# Altium schematic sheet WPT Round 2.1 RX Schematics.SchDoc
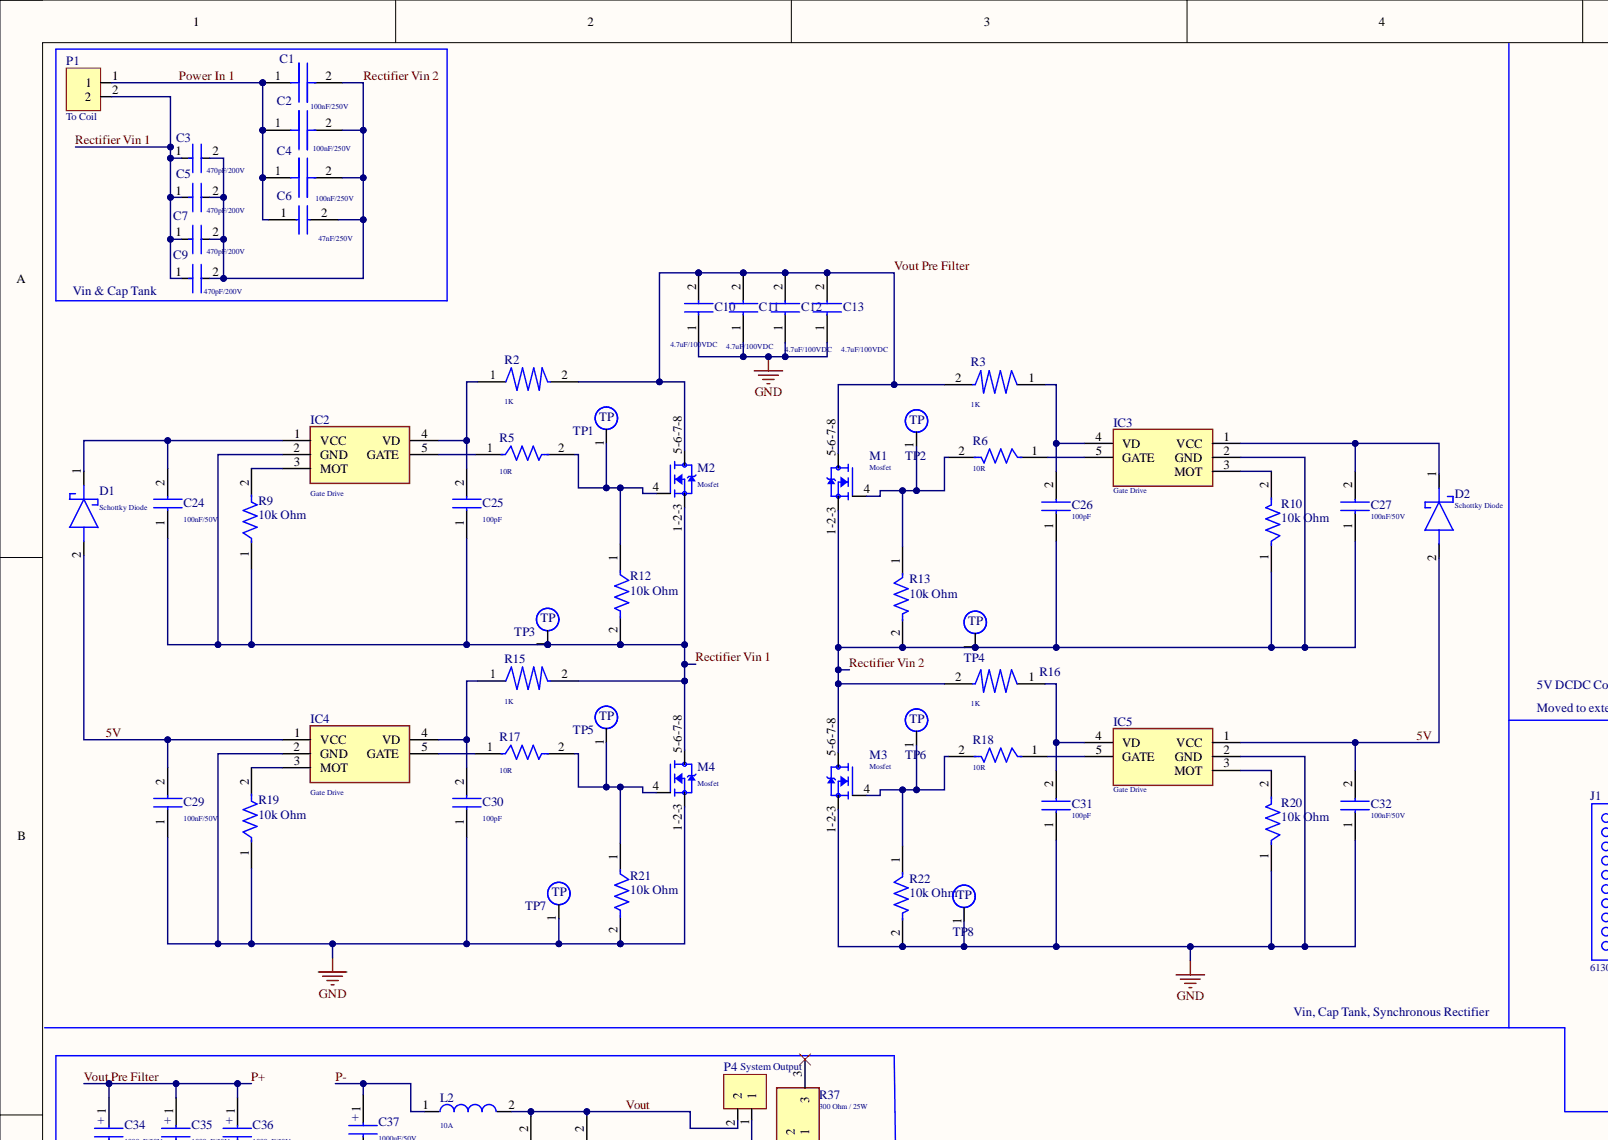
[diagram p0: WPT Round 2.1 RX Schematics.SchDoc, top left]
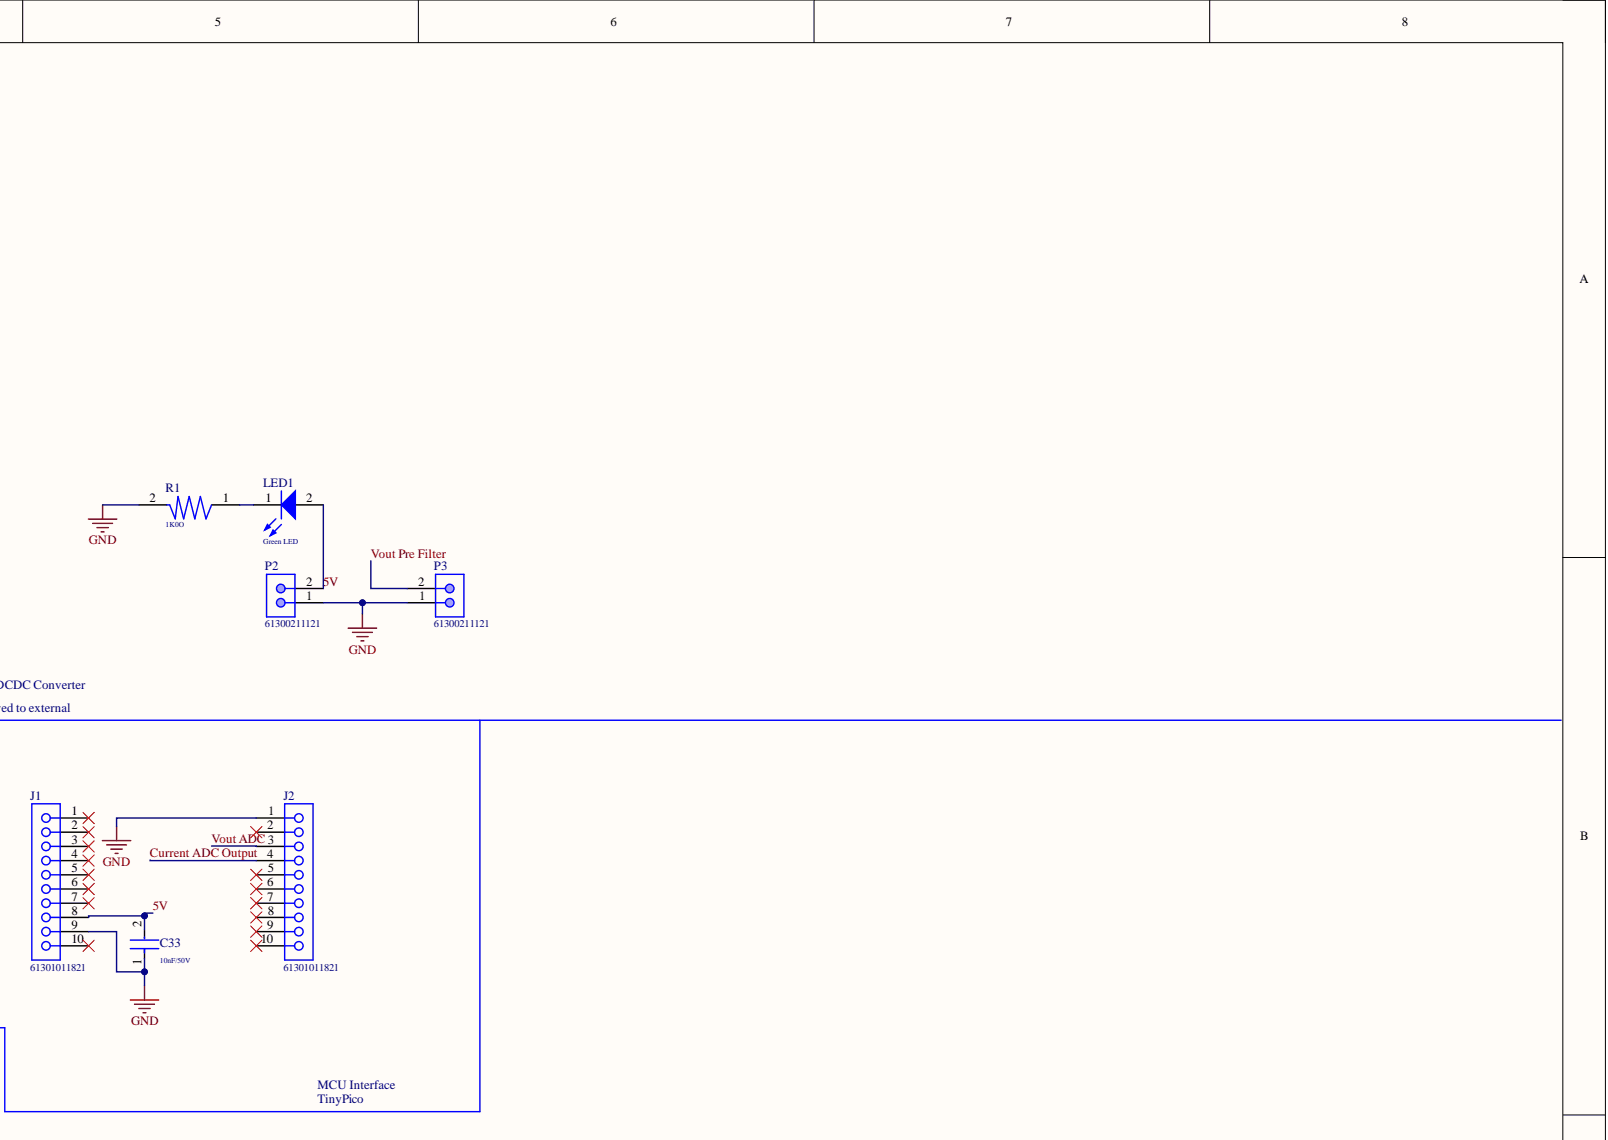
[diagram p1: WPT Round 2.1 RX Schematics.SchDoc, top right]
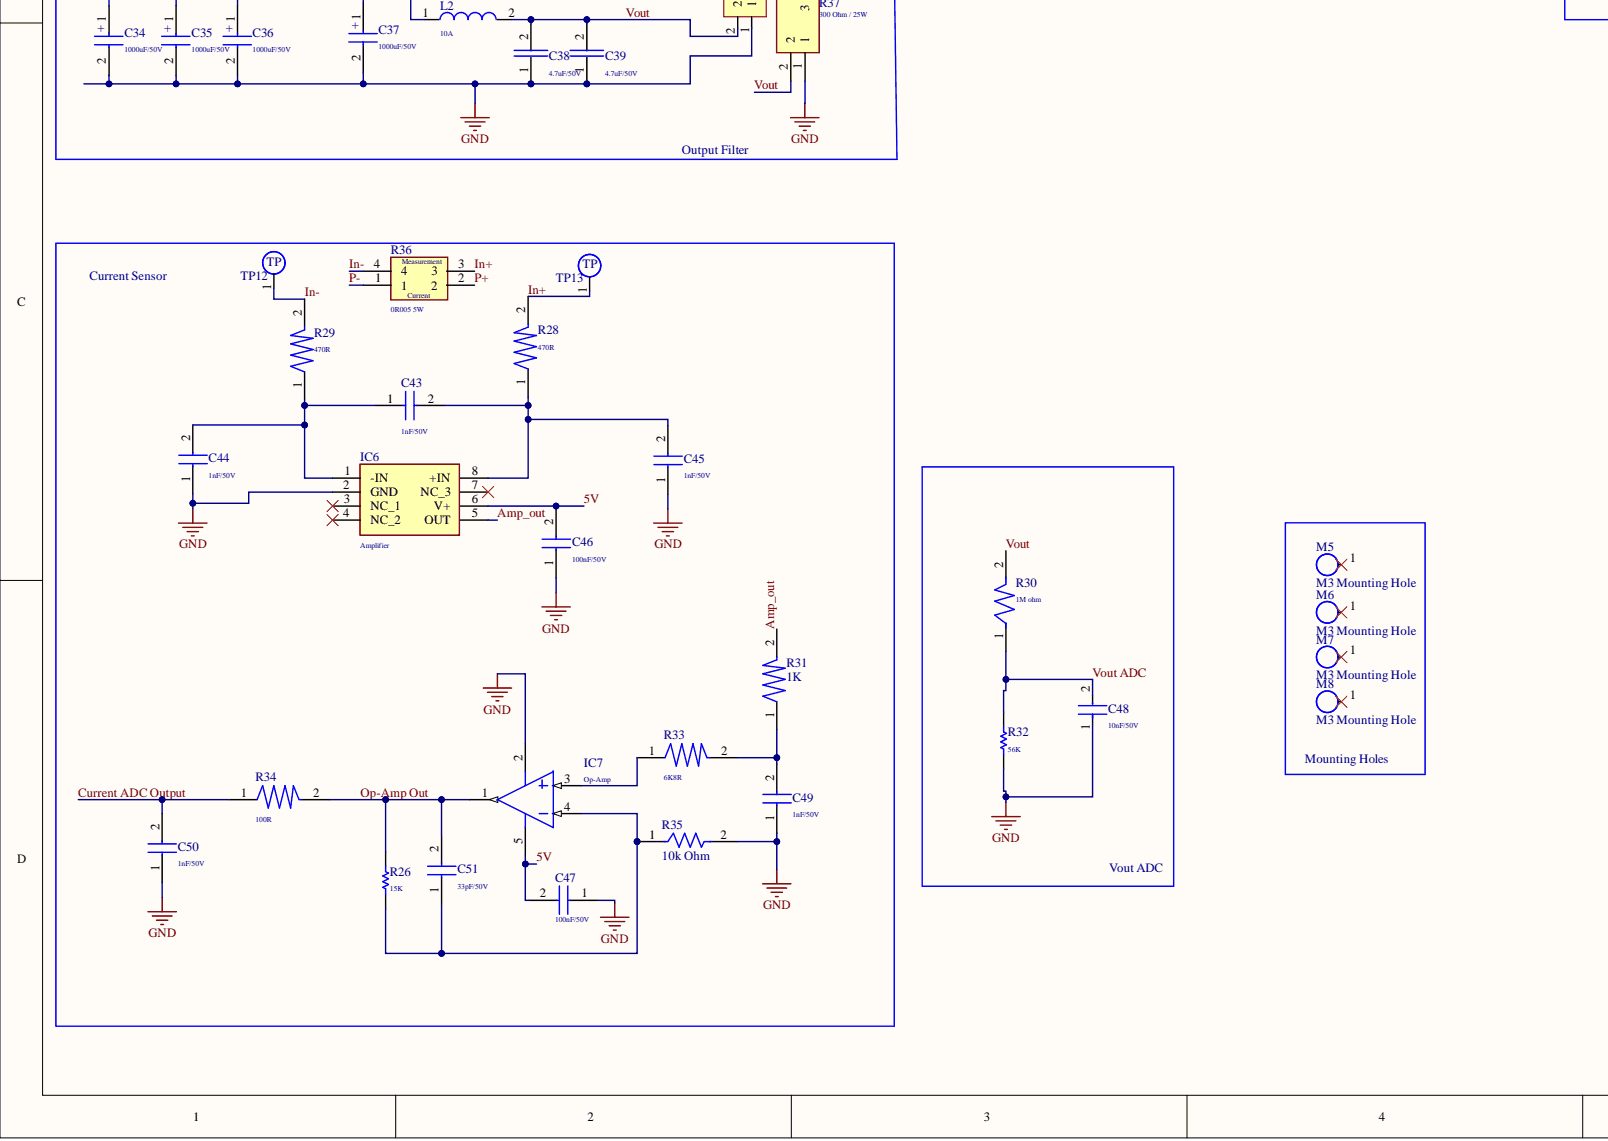
[diagram p2: WPT Round 2.1 RX Schematics.SchDoc, bottom left]
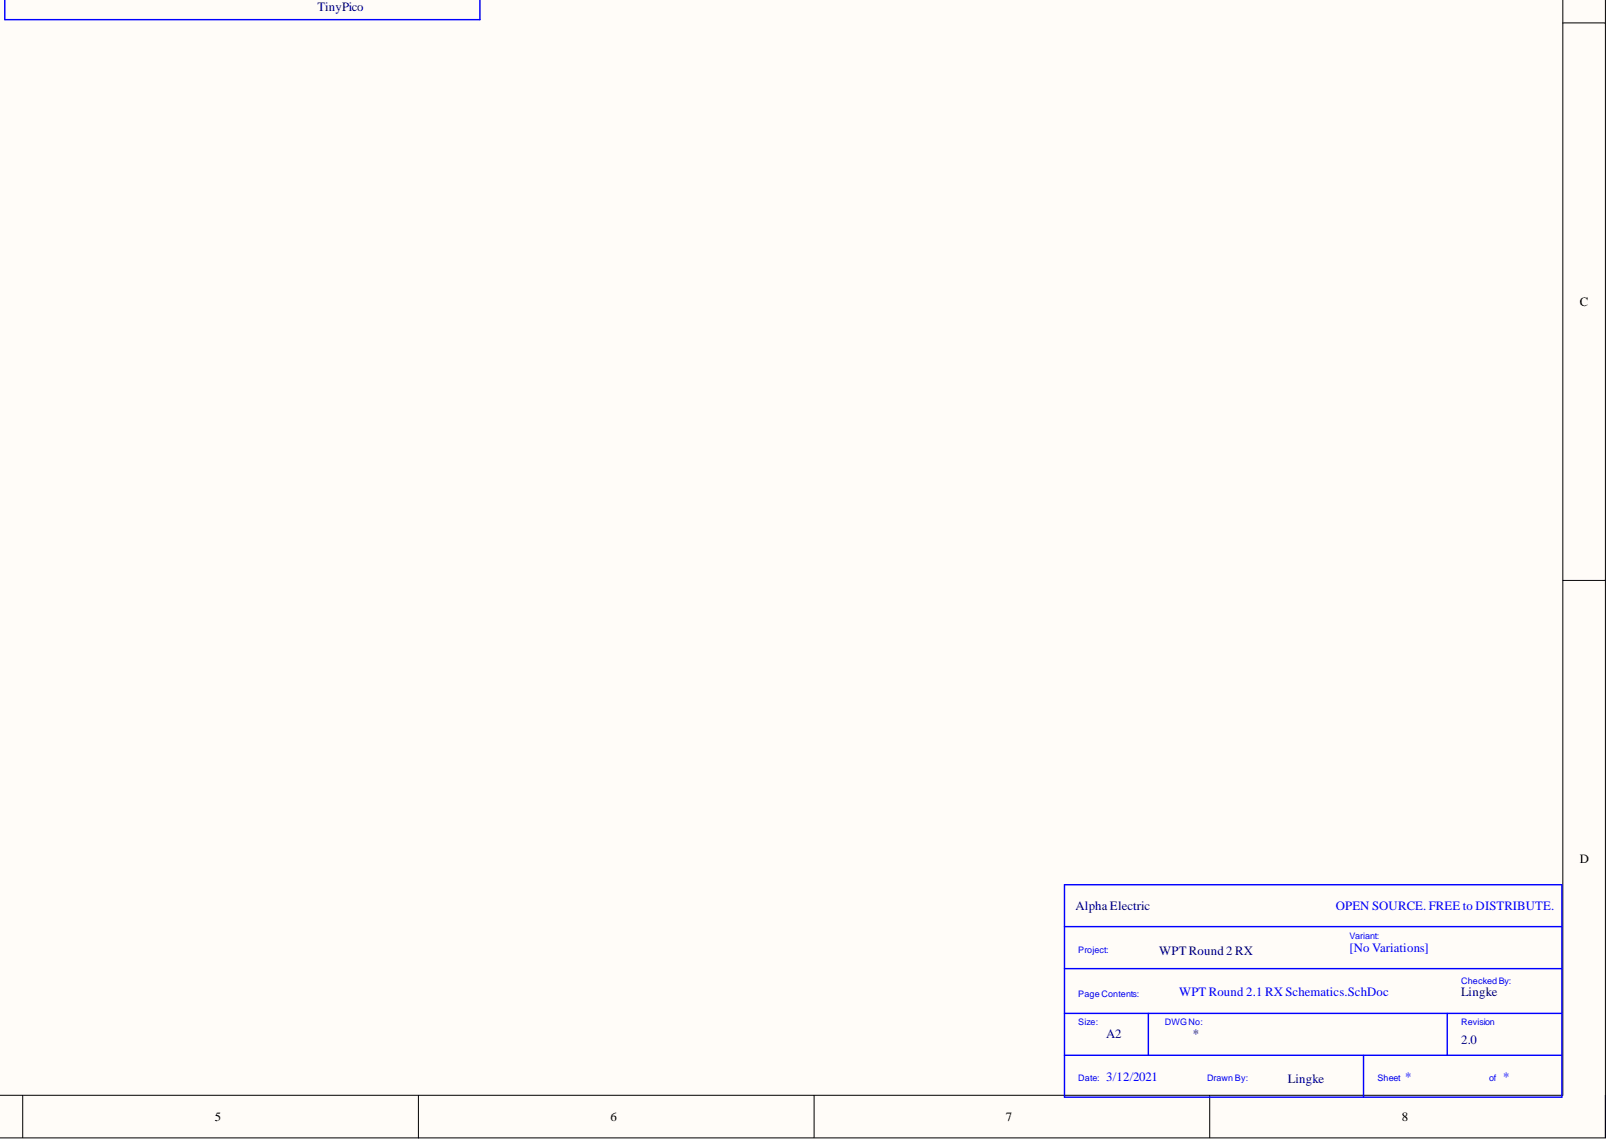
[diagram p3: WPT Round 2.1 RX Schematics.SchDoc, bottom right]

## Parts
*  Checked By: Lingke  Company: Alpha Electric  Project Name: WPT Round 2 RX  Revision: 2.0  Size: A2  (hidden comment: Title_Block)  (no BOM)
C1  100nF/250V  [CAPC4532X220N]
C2  100nF/250V  [CAPC4532X220N]
C3  470pF/200V  [FP-C1206C-EB-MFG]
C4  100nF/250V  [CAPC4532X220N]
C5  470pF/200V  [FP-C1206C-EB-MFG]
C6  47nF/250V  [CAPC4532X350N]
C7  470pF/200V  [FP-C1206C-EB-MFG]
C9  470pF/200V  [FP-C1206C-EB-MFG]
C10  4.7uF/100VDC  [CAPC3225X280N]
C11  4.7uF/100VDC  [CAPC3225X280N]
C12  4.7uF/100VDC  [CAPC3225X280N]
C13  4.7uF/100VDC  [CAPC3225X280N]
C24  100nF/50V  [CAPC2012X90N]
C25  100pF  [CAPC2012X70N]
C26  100pF  [CAPC2012X70N]
C27  100nF/50V  [CAPC2012X90N]
C29  100nF/50V  [CAPC2012X90N]
C30  100pF  [CAPC2012X70N]
C31  100pF  [CAPC2012X70N]
C32  100nF/50V  [CAPC2012X90N]
C33  10nF/50V  [0805 Capacitor]
C34  1000uF/50V  [CAPPRD500W62D1300H2650]
C35  1000uF/50V  [CAPPRD500W62D1300H2650]
C36  1000uF/50V  [CAPPRD500W62D1300H2650]
C37  1000uF/50V  [CAPPRD500W62D1300H2650]
C38  4.7uF/50V  [CAPC3225X280N]
C39  4.7uF/50V  [CAPC3225X280N]
C43  1nF/50V  [CAPC2012X90N]
C44  1nF/50V  [CAPC2012X90N]
C45  1nF/50V  [CAPC2012X90N]
C46  100nF/50V  [CAPC2012X90N]
C47  100nF/50V  [CAPC2012X90N]
C48  10nF/50V  [0805 Capacitor]
C49  1nF/50V  [CAPC2012X90N]
C50  1nF/50V  [CAPC2012X90N]
C51  33pF/50V  [CAPC2012X90N]
D1  Schottky Diode  [RB400VAM50TR]
D2  Schottky Diode  [RB400VAM50TR]
IC2  Gate Drive  [SOT95P280X145-5N]
IC3  Gate Drive  [SOT95P280X145-5N]
IC4  Gate Drive  [SOT95P280X145-5N]
IC5  Gate Drive  [SOT95P280X145-5N]
IC6  Amplifier  [SOIC127P600X175-8N]
IC7  Op-Amp  [ADI-RJ-5_N]
J1  61301011821  [61301011821]
J2  61301011821  [61301011821]
L2  10A  [WE-PF_1280]
LED1  Green LED  Color: Green  [0805_A]
M1  Mosfet  [TRANS_BSZ037N06LS5ATMA1]
M2  Mosfet  [TRANS_BSZ037N06LS5ATMA1]
M3  Mosfet  [TRANS_BSZ037N06LS5ATMA1]
M4  Mosfet  [TRANS_BSZ037N06LS5ATMA1]
M5  M3 Mounting Hole  [M3 Hole]
M6  M3 Mounting Hole  [M3 Hole]
M7  M3 Mounting Hole  [M3 Hole]
M8  M3 Mounting Hole  [M3 Hole]
P1  To Coil  [691404910002B]
P2  61300211121  [61300211121]
P3  61300211121  [61300211121]
P4  System Output  [691404910002B]
R1  1K0O  [FP-CRCW0805-e3-MFG]
R2  1K  [FP-CRCW0805-e3-MFG]
R3  1K  [FP-CRCW0805-e3-MFG]
R5  10R  [FP-CRCW1206-e3-IPC_A]
R6  10R  [FP-CRCW1206-e3-IPC_A]
R9  10k Ohm  [0805 Resistor]
R10  10k Ohm  [0805 Resistor]
R12  10k Ohm  [0805 Resistor]
R13  10k Ohm  [0805 Resistor]
R15  1K  [FP-CRCW0805-e3-MFG]
R16  1K  [FP-CRCW0805-e3-MFG]
R17  10R  [FP-CRCW1206-e3-IPC_A]
R18  10R  [FP-CRCW1206-e3-IPC_A]
R19  10k Ohm  [0805 Resistor]
R20  10k Ohm  [0805 Resistor]
R21  10k Ohm  [0805 Resistor]
R22  10k Ohm  [0805 Resistor]
R26  15K  [0805 Resistor]
R28  470R  [FP-CRCW0805-e3-MFG]
R29  470R  [FP-CRCW0805-e3-MFG]
R30  1M ohm  [FP-CRCW0805-e3-MFG]
R31  1K  [FP-CRCW0805-e3-MFG]
R32  56K  [0805 Resistor]
R33  6K8R  [FP-CRCW0805-e3-MFG]
R34  100R  [FP-CRCW0805-e3-MFG]
R35  10k Ohm  [0805 Resistor]
R36  0R005 5W  [FC4L110R005FER]
R37  300 Ohm / 25W  [PWR163S253000FE]
TP1  TP  [Test Point]  (no BOM)
TP2  TP  [Test Point]  (no BOM)
TP3  TP  [Test Point]  (no BOM)
TP4  TP  [Test Point]  (no BOM)
TP5  TP  [Test Point]  (no BOM)
TP6  TP  [Test Point]  (no BOM)
TP7  TP  [Test Point]  (no BOM)
TP8  TP  [Test Point]  (no BOM)
TP12  TP  [Test Point]  (no BOM)
TP13  TP  [Test Point]  (no BOM)

## Nets
GND: C10.1 C11.1 C12.1 C13.1 C29.1 C30.1 C31.1 C32.1 C33.1 C34.2 C35.2 C36.2 C37.2 C38.1 C39.1 C44.1 C45.1 C46.1 C47.1 C48.1 C49.1 C50.1 IC4.2(GND) IC5.2(GND) IC6.2(GND) IC7.2 J1.9 J2.1 M3.1-2-3 M4.1-2-3 P2.1 P3.1 P4.1 R1.2 R19.1 R20.1 R21.2 R22.2 R32.1 R35.2 R37.1 TP7.1 TP8.1
5V: C29.2 C32.2 C33.2 C46.2 C47.2 D1.2 D2.2 IC4.1(VCC) IC5.1(VCC) IC6.6(V+) IC7.5 J1.8 LED1.2 P2.2
Amp_out: IC6.5(OUT) R31.2
Current ADC Output: C50.2 J2.4 R34.1
In+: R28.2 R36.3 TP13.1
In-: R29.2 R36.4 TP12.1
Op-Amp Out: C51.2 IC7.1 R26.2 R34.2
P+: C10.2 C11.2 C12.2 C13.2 C34.1 C35.1 C36.1 M1.5-6-7-8 M2.5-6-7-8 P3.2 R2.2 R3.2 R36.2
P-: C37.1 L2.1 R36.1
Power In 1: C1.1 C2.1 C4.1 C6.1 P1.1
Rectifier Vin 1: C3.1 C5.1 C7.1 C9.1 C24.1 C25.1 IC2.2(GND) M2.1-2-3 M4.5-6-7-8 P1.2 R9.1 R12.2 R15.2 TP3.1
Rectifier Vin 2: C1.2 C2.2 C3.2 C4.2 C5.2 C6.2 C7.2 C9.2 C26.1 C27.1 IC3.2(GND) M1.1-2-3 M3.5-6-7-8 R10.1 R13.2 R16.2 TP4.1
Vout: C38.2 C39.2 L2.2 P4.2 R30.2 R37.2
Vout ADC: C48.2 J2.3 R30.1 R32.2
NetC24_2: C24.2 D1.1 IC2.1(VCC)
NetC25_2: C25.2 IC2.4(VD) R2.1
NetC26_2: C26.2 IC3.4(VD) R3.1
NetC27_2: C27.2 D2.1 IC3.1(VCC)
NetC30_2: C30.2 IC4.4(VD) R15.1
NetC31_2: C31.2 IC5.4(VD) R16.1
NetC43_1: C43.1 C44.2 IC6.1(-IN) R29.1
NetC43_2: C43.2 C45.2 IC6.8(+IN) R28.1
NetC49_2: C49.2 R31.1 R33.2
NetC51_1: C51.1 IC7.4 R26.1 R35.1
NetIC2_3: IC2.3(MOT) R9.2
NetIC2_5: IC2.5(GATE) R5.1
NetIC3_3: IC3.3(MOT) R10.2
NetIC3_5: IC3.5(GATE) R6.1
NetIC4_3: IC4.3(MOT) R19.2
NetIC4_5: IC4.5(GATE) R17.1
NetIC5_3: IC5.3(MOT) R20.2
NetIC5_5: IC5.5(GATE) R18.1
NetIC7_3: IC7.3 R33.1
NetLED1_1: LED1.1 R1.1
NetM1_4: M1.4 R6.2 R13.1 TP2.1
NetM2_4: M2.4 R5.2 R12.1 TP1.1
NetM3_4: M3.4 R18.2 R22.1 TP6.1
NetM4_4: M4.4 R17.2 R21.1 TP5.1

Not connected: IC6 3(NC_1) 4(NC_2) 7(NC_3); J1 1 2 3 4 5 6 7 10; J2 2 5 6 7 8 9 10; M5 1; M6 1; M7 1; M8 1; R37 3

## Library data of the parts
* = Title_Block: Standard Title Block.; Library Name: Symbol Library.SchLib; Drawn By: Lingke
C1 C2 C4 = C1812C104JAGACAUTO: Manufacturer_Name: Kemet; Component Kind: Standard; Datasheet Link: https://api.kemet.com/component-edge/download/datasheet/C1812C104JAGACAUTO.pdf; Description: 100nF/250V; Height: 2.2mm; Library Name: Symbol Library.SchLib; Manufacturer_Part_Number: C1812C104JAGACAUTO; Supplier 1: Mouser; Supplier Part Number 1: 80-C1812C104JAGAUTO
C3 C5 C7 C9 = C1206C471K2GACTU: CAP CER 470PF 200V 10% NP0 1206; Manufacturer: KEMET; Automotive Grade: Grade 0; Material: Ceramic; Temperature Characteristic: C0G; Temperature Coefficient: 15%; Tolerance: 0.1; Automotive: Yes; Capacitance: 470 pF; Category: Cap; Component Kind: Standard; ComponentLink2Description: Datasheet; ComponentLink2URL: https://content.kemet.com/datasheets/KEM_C1007_X8R_ULTRA_150C_SMD.pdf; Device Class L1: Passive Components; Device Class L2: Capacitors; Device Class L3: Ceramic Capacitors; Digikey Description: CAP CER 470PF 200V 10% NP0 1206; Height: 0.88mm; Ipc Land Pattern Name: CAPC320160X78; Lead Free: Yes; Library Name: Symbol Library.SchLib; Manufacturer Part Number: C1206C471K2GACTU; Mouser Description: Multilayer Ceramic Capacitors MLCC - SMD/SMT 200volts 470pF C0G 10%; Octopart: https://octopart.com/c1206c471k2gactu-kemet-3658280; Package: C1206C-EB; RoHS: TRUE; Temperature: -55°C to +150°C; Temperature Range High: +150°C; Temperature Range Low: -55°C; Value: 470 pF; Voltage Rating: 200 V
C6 = C4532C0G2E473J320KA: Capacitor  47nF/250V   C0G; Manufacturer_Name: TDK; Component Kind: Standard; Datasheet Link: https://product.tdk.com/info/en/catalog/datasheets/mlcc_commercial_midvoltage_en.pdf; Height: 3.5mm; Library Name: Symbol Library.SchLib; Manufacturer_Part_Number: C4532C0G2E473J320KA; Supplier 1: Mouser; Supplier Part Number 1: 810-C4532C0G2E473J
C10-C13 = CNC6P1X7R2A475K250AE: Manufacturer_Name: TDK; Component Kind: Standard; Datasheet Link: https://componentsearchengine.com//CNC6P1X7R2A475K250AE.pdf; Description: 4.7uF/100VDC; Height: 2.8mm; Library Name: Symbol Library.SchLib; Manufacturer_Part_Number: CNC6P1X7R2A475K250AE; Mouser2 Part Number: 810-CNC6P1X7R2A475K2; Mouser2 Price/Stock: https://www.mouser.com/Search/Refine.aspx?Keyword=810-CNC6P1X7R2A475K2; Supplier 1: Mouser; Supplier Part Number 1: 810-CNC6P1X7R2A475K2
C24 C27 C29 C32 = 885012207098: Capacitor 100nF/50V; Manufacturer_Name: Wurth Elektronik; Component Kind: Standard; Datasheet Link: https://componentsearchengine.com//885012207098.pdf; Height: 0.9mm; Library Name: Symbol Library.SchLib; Manufacturer_Part_Number: 885012207098; Mouser2 Part Number: 710-885012207098; Mouser2 Price/Stock: https://www.mouser.com/Search/Refine.aspx?Keyword=710-885012207098; Supplier 1: Mouser; Supplier Part Number 1: 710-885012207098
C25 C26 C30 C31 = 885012007057: Capacitor 100pF; Manufacturer_Name: Wurth Elektronik; Component Kind: Standard; Datasheet Link: https://katalog.we-online.com/pbs/datasheet/885012007057.pdf; Height: 0.7mm; Library Name: Symbol Library.SchLib; Manufacturer_Part_Number: 885012007057; Supplier 1: Mouser; Supplier Part Number 1: 710-885012007057
C33 C48 = 885012207092: Component Kind: Standard; Description: 10nF/50V; Library Name: Symbol Library.SchLib; Supplier 1: Mouser; Supplier Part Number 1: 710-885012207092
C34-C37 = ESH108M050AL4AA: Capacitor 1000uF; Component Kind: Standard; Library Name: Symbol Library.SchLib; Supplier 1: Mouser; Supplier Part Number 1: 80-ESH108M050AL4AA
C38 C39 = 885012209048: Capacitor 4.7uF/50V; Manufacturer_Name: Wurth Elektronik; Component Kind: Standard; Datasheet Link: https://katalog.we-online.com/pbs/datasheet/885012209048.pdf; Height: 2.8mm; Library Name: Symbol Library.SchLib; Manufacturer_Part_Number: 885012209048; Supplier 1: Mouser; Supplier Part Number 1: 710-885012209048
C43-C45 C49 C50 = 885012207086: Manufacturer_Name: Wurth Elektronik; Component Kind: Standard; Datasheet Link: https://katalog.we-online.com/pbs/datasheet/885012207086.pdf; Description: 1nF/50V; Height: 0.9mm; Library Name: Symbol Library.SchLib; Manufacturer_Part_Number: 885012207086; Supplier 1: Mouser; Supplier Part Number 1: 710-885012207086
C46 C47 = 885012207098: Manufacturer_Name: Wurth Elektronik; Component Kind: Standard; Description: 100nF/50V; Height: 0.9mm; Library Name: Symbol Library.SchLib; Supplier 1: Mouser; Supplier Part Number 1: 710-885012207098
C51 = C0805C330J5GACTU: Capacitor 33pF/50V; Manufacturer_Name: Kemet; Component Kind: Standard; Library Name: Symbol Library.SchLib; Manufacturer_Part_Number: C0805C330J5GACTU; Supplier 1: Mouser; Supplier Part Number 1: 80-C0805C330J5G
D1 D2 = RB400VAM-50TR: Manufacturer_Name: ROHM Semiconductor; Component Kind: Standard; Datasheet Link: https://componentsearchengine.com//RB400VAM-50TR.pdf; Description: Schottky Diode; Height: 0.8mm; Library Name: Symbol Library.SchLib; Manufacturer_Part_Number: RB400VAM-50TR; Mouser2 Part Number: 755-RB400VAM-50TR; Mouser2 Price/Stock: https://www.mouser.com/Search/Refine.aspx?Keyword=755-RB400VAM-50TR; Supplier 1: Mouser; Supplier Part Number 1: 755-RB400VAM-50TR
IC2-IC5 = IR1161LTRPBF: Gate Driver IC; Manufacturer_Name: Infineon; Component Kind: Standard; Height: 1.45mm; Library Name: Symbol Library.SchLib; Manufacturer_Part_Number: IR1161LTRPBF; Supplier 1: Mouser; Supplier Part Number 1: 942-IR1161LTRPBF
IC6 = AD8215YRZ: Integrated Circuit Amplifier; Manufacturer_Name: Analog Devices; Component Kind: Standard; Datasheet Link: http://www.analog.com/static/imported-files/data_sheets/AD8215.pdf; Height: 1.75mm; Library Name: Symbol Library.SchLib; Manufacturer_Part_Number: AD8215YRZ; Supplier 1: Mouser; Supplier Part Number 1: 584-AD8215YRZ
IC7 = AD8031ARTZ-REEL7: Single Channel RRIO Operational Amplifier, 80 MHz BW, 35 V/us SR, Industrial, 5-pin SOT23 (RJ-5), Reel; Generic Part Number: AD8031; Manufacturer: Analog Devices; Amplifiers Per Package: 1; Input Bias Current: 450nA; Input Offset Voltage: 1mV; Iq/Amp: 900uA; Slew Rate: 35V/us; Small Signal Bandwidth: 80MHz; V Span Max: 12V; V Span Min: 2.7V; Code_JEDEC: MO-178-AA; Component Kind: Standard; ComponentLink1Description: Manufacturer URL; ComponentLink1URL: http://www.analog.com/en/index.html; ComponentLink2Description: Datasheet; ComponentLink2URL: http://www.analog.com/static/imported-files/data_sheets/AD8031_8032.pdf; ComponentLink3Description: Package Specification; ComponentLink3URL: http://www.analog.com/static/imported-files/packages/PKG_PDF/SOT-23(RJ)/RJ_5.pdf; ComponentLink4Description: Reference Circuit; ComponentLink4URL: http://www.analog.com/en/all-operational-amplifiers-op-amps/operational-amplifiers-op-amps/ad8031/products/product.html#product-recommendations; DatasheetVersion: Rev. D; Library Name: Symbol Library.SchLib; Mounting Technology: Surface Mount; PackageDescription: 5-Pin Small Outline Transistor Package, Body 2.9 x 1.6 mm, Pitch 0.95 mm; PackageReference: RJ-5; PackageVersion: 121608-A; Packing: Reel; PartNumber: AD8031ARTZ-REEL7; RevisionNote: Reference Circuit URL fixed; Supplier 1: Mouser; Supplier Part Number 1: 584-AD8031ARTZ-R7
J1 J2 = 61301011821: WR-PHD Socket Header, THT, pitch 2.54mm, Single Row, Vertical, 10p; Manufacturer: Wurth Elektronik; Contact Resistance: 20mOhm; Pin Number: 10; Rated Current: 3A; Withstanding Voltage: 500VAC; Working Voltage: 250VAC; Component Kind: Standard; ComponentLink1Description: Manufacturer; ComponentLink1URL: http://www.we-online.de; ComponentLink2Description: Datasheet; ComponentLink2URL: https://www.we-online.com/catalog/datasheet/61301011821.pdf; Gender: Socket Header; Library Name: Altium_WR-PHD (rev20a).IntLib; Manufacturer Part Number: 61301011821; Mounting Technology: THT; Operating Temperature: -40 to +125degC; Orientation: Vertical; Packaging: Tray; Pitch: 2.54mm; Published Date: May 2020; Row Number: Single
L2 = 742792901: SMD EMI Suppression Power Ferrite WE-PF, Z = 2900 Ohm; Component Kind: Standard; ComponentLink1Description: Manufacturer Link; ComponentLink1URL: http://www.we-online.com; ComponentLink2Description: Datasheet; ComponentLink2URL: http://www.we-online.de/katalog/media/pdf/742792901.pdf; DatasheetVersion: ver 6, Apr-2007; Impedance: 2900 Ohm; Library Name: Symbol Library.SchLib; PackageDescription: Inductor, 2-Leads, Body 12.0 x 12.0 mm; PackageReference: WE-PF; PackageVersion: 2007; Supplier 1: Mouser; Supplier Part Number 1: 710-742792901
LED1 = 150080GS75000: SMD mono-color Chip LED, WL-SMCW, Green; Manufacturer: Wuerth Elektronik; Component Kind: Standard; ComponentLink1Description: Manufacturer URL; ComponentLink1URL: http://www.we-online.com; ComponentLink2Description: Datasheet; ComponentLink2URL: http://katalog.we-online.com/led/datasheet/150080GS75000.pdf; Library Name: Symbol Library.SchLib; PackageReference: 0805_A; PartNumber: 150080GS75000; Supplier 1: Mouser; Supplier Part Number 1: 710-150080GS75000
M1-M4 = BSZ070N08LS5ATMA1: Further performance improvements with best-in-class OptiMOSâ„¢ 5 60V power MOSFET in high performance PQFN 3.3x3.3mm package  MOSFET Diode; MF: Infineon Technologies; MP: BSZ037N06LS5ATMA1; Availability: Unavailable; Component Kind: Standard; Library Name: Symbol Library.SchLib; Package: TSDSON-8 Infineon Technologies; Price: None; SnapEDA_Link: https://www.snapeda.com/parts/BSZ037N06LS5ATMA1/Infineon%20Technologies/view-part/4422719/?ref=snap; Supplier 1: Mouser; Supplier Part Number 1: 726-BSZ070N08LS5ATMA
M5-M8 = M3_Mounting_hole: Component Kind: Standard; Library Name: Symbol Library.SchLib
P1 P4 = 691404910002B: Serie 4049 B - 7.50mm Screwless Clamp Power Connector WR-TBL, 2 pin; Manufacturer: Wurth Elektronik; Pin Number: 2; Component Kind: Standard; Datasheet URL: http://katalog.we-online.de/em/datasheet/6914049100xxB.pdf; Library Name: Symbol Library.SchLib; Manufacturer URL: http://www.we-online.de; Mounting Technology: THT; Operating Temperature: -40to105degC; Package Reference: 691404910002B; Packaging: Box; Part Number: 691404910002B; Pitch: 7.50mm; Supplier 1: Mouser; Supplier Part Number 1: 710-691404910002B; Publisher: WurthElektronik
P2 P3 = 61300211121: WR-PHD Pin Header, THT, pitch 2.54mm, Single Row, Vertical, 2p; Manufacturer: Wurth Elektronik; Contact Resistance: 20mOhm; Pin Number: 2; Rated Current: 3A; Withstanding Voltage: 500VAC; Working Voltage: 250VAC; Component Kind: Standard; ComponentLink1Description: Manufacturer; ComponentLink1URL: http://www.we-online.de; ComponentLink2Description: Datasheet; ComponentLink2URL: https://www.we-online.com/catalog/datasheet/61300211121.pdf; Gender: Pin Header; Library Name: Altium_WR-PHD (rev20a).IntLib; Manufacturer Part Number: 61300211121; Mounting Technology: THT; Operating Temperature: -40 to +105degC; Orientation: Vertical; Packaging: Bag; Pitch: 2.54mm; Published Date: May 2020; Row Number: Single
R1 = CRCW08051K00FKEAC: Resistor 1K0 Ohm; Component Kind: Standard; Library Name: Symbol Library.SchLib; Number of Terminations: 2; Supplier 1: Mouser; Supplier Part Number 1: 71-CRCW0805-1.0K-E3
R2 R3 R15 R16 R31 = CRCW08051K00FKEA: Resistor 1k Ohm; Component Kind: Standard; Library Name: Symbol Library.SchLib; Number of Terminations: 2; Supplier 1: Mouser; Supplier Part Number 1: 71-CRCW0805-1.0K-E3
R5 R6 R17 R18 = CRCW120610R0FKEA: RES Thick Film, 10Ω, 1%, 0.25W, 100ppm/°C, 1206  MOSFET Gate Resistor 10Ohm; Max Power Dissipation: 250mW; Voltage Rating: 200V; Case Code (Imperial): 1206; Case Code (Metric): 3216; Case/Package: 1206; Component Kind: Standard; Composition: Thick Film; Contact Plating: Tin; Depth: 1.6mm; Features: Automotive AEC-Q200; Height: 0.55mm; Lead Free: Lead Free; Length: 3.2mm; Library Name: Symbol Library.SchLib; Max Operating Temperature: 155°C; Military Standard: Not; Min Operating Temperature: -55°C; Number of Pins: 2; Number of Terminations: 2; Package Quantity: 10; Packaging: Tape and Reel; Power Rating: 250mW; Radiation Hardening: No; Resistance: 10R; Supplier 1: Digi-Key; Supplier Part Number 1: 541-10.0FDKR-ND; Temperature Coefficient: 100ppm/°C; Termination: SMD/SMT; Tolerance: 1%; Voltage Rating (DC): 200V; Weight: 0.000353oz; Width: 0.063inch
R9 R10 R12 R13 R19-R22 R35 = CRCW080510K0FKEA: RES Thick Film, 10kΩ, 1%, 0.125W, 100ppm/°C, 0805  10k Ohm; Power Rating: 125mW; Resistance: 10kR; Voltage Rating: 150V; Case Code (Imperial): 0805; Case Code (Metric): 2012; Case/Package: 0805; Component Kind: Standard; Composition: Thick Film; Contact Plating: Tin; Depth: 1.25mm; Height: 0.45mm; Lead Free: Lead Free; Length: 2mm; Library Name: Symbol Library.SchLib; Max Operating Temperature: 155°C; Military Standard: Not; Min Operating Temperature: -55°C; Package Quantity: 5000; Packaging: Tape and Reel; Radiation Hardening: No; Supplier 1: Mouser; Supplier Part Number 1: 71-CRCW0805-10K-E3; Temperature Coefficient: 100ppm/°C; Termination: SMD/SMT; Tolerance: 1%; Voltage Rating (DC): 150V; Weight: 0.000194oz; Width: 1.25mm
R26 = ERA-6AED153V: 15K Ohm Resistor; Component Kind: Standard; Library Name: Symbol Library.SchLib; Supplier 1: Mouser; Supplier Part Number 1: 667-ERA-6AED153V
R28 R29 = CRCW0805470RFKEB: Resistor 470 Ohm; Component Kind: Standard; Library Name: Symbol Library.SchLib; Number of Terminations: 2; Supplier 1: Mouser; Supplier Part Number 1: 71-CRCW0805470RFKEB
R30 = CRCW08051M00FKEA: RES Thick Film, 1MΩ, 1%, 0.125W, 100ppm/°C, 0805  1M Ohm Resistor; Power Rating: 125mW; Resistance: 1MR; Voltage Rating: 150V; Case Code (Imperial): 0805; Case Code (Metric): 2012; Case/Package: 0805; Component Kind: Standard; Composition: Thick Film; Contact Plating: Tin; Depth: 1.25mm; Height: 0.45mm; Lead Free: Lead Free; Length: 2mm; Library Name: Symbol Library.SchLib; Max Operating Temperature: 155°C; Military Standard: Not; Min Operating Temperature: -55°C; Mount: Surface Mount; Number of Pins: 2; Package Quantity: 5000; Packaging: Tape and Reel; Radiation Hardening: No; Supplier 1: Mouser; Supplier Part Number 1: 71-CRCW08051M00FKEAH; Temperature Coefficient: 100ppm/°C; Termination: SMD/SMT; Tolerance: 1%; Voltage Rating (DC): 150V; Weight: 0.000194oz; Width: 1.25mm
R32 = ERJ-6ENF5622V: 56K Ohm Resistor; Component Kind: Standard; Library Name: Symbol Library.SchLib; Supplier 1: Mouser; Supplier Part Number 1: 667-ERJ-6ENF5622V
R33 = CRCW08056K80FKEA: Resistor 6.8K Ohm; Component Kind: Standard; Library Name: Symbol Library.SchLib; Number of Terminations: 2; Supplier 1: Mouser; Supplier Part Number 1: 71-CRCW0805-6.8K-E3
R34 = CRCW0805100RFKEB: Resistor 100 Ohm; Component Kind: Standard; Library Name: Symbol Library.SchLib; Number of Terminations: 2; Supplier 1: Mouser; Supplier Part Number 1: 71-CRCW0805100RFKEB
R36 = FC4L110R005FER: Resistor 0R005 5W; Manufacturer_Name: Ohmite; Component Kind: Standard; Height: 0.7mm; Library Name: Symbol Library.SchLib; Manufacturer_Part_Number: FC4L110R005FER; Supplier 1: Mouser; Supplier Part Number 1: 588-FC4L110R005FER
R37 = PWR163S-25-3000FE: Resistor 300 Ohm / 25W; Manufacturer_Name: Bourns; Component Kind: Standard; Datasheet Link: https://componentsearchengine.com/Datasheets/1/PWR163S-25-3000FE.pdf; Height: 2.79mm; Library Name: Symbol Library.SchLib; Manufacturer_Part_Number: PWR163S-25-3000FE; Supplier 1: Mouser; Supplier Part Number 1: 652-PWR163S253000FE
TP1-TP8 TP12 TP13 = Test Point: Test Point 1mm by 1mm; Library Name: Symbol Library.SchLib
